# Altium schematic sheet OpenBeacon.SchDoc
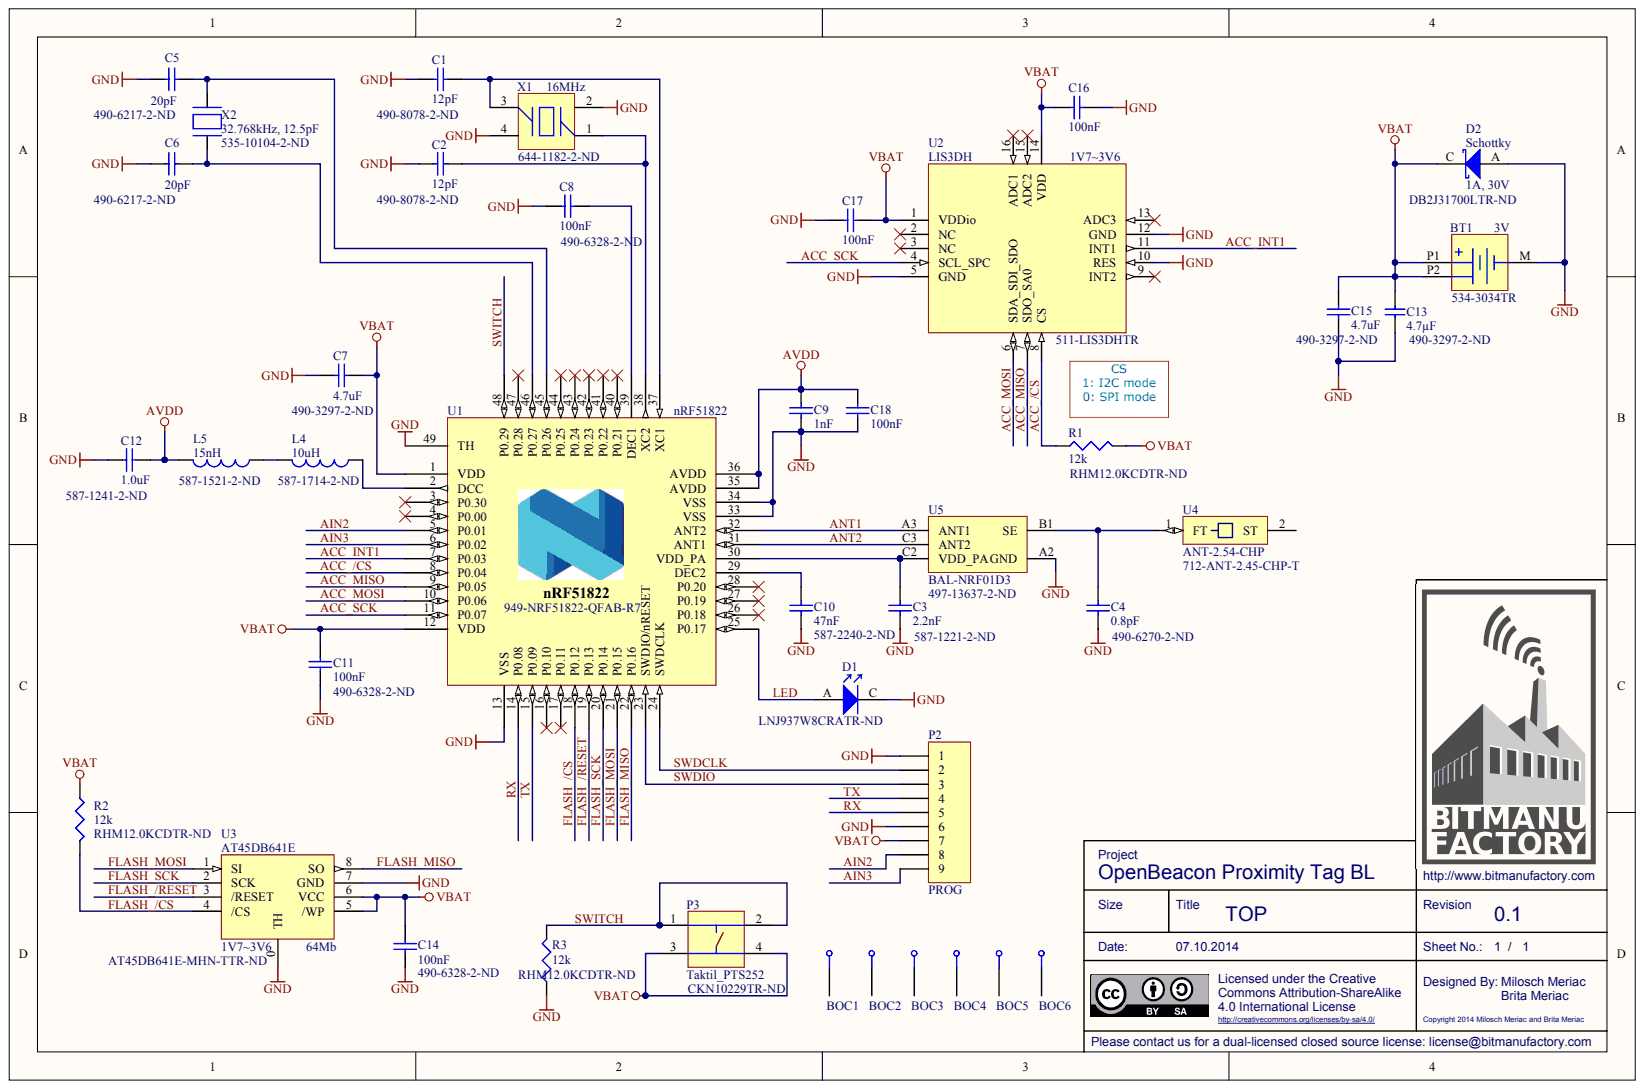
[diagram p0: OpenBeacon.SchDoc]

## Parts
BOC1  Testpad  (value not drawn)
BOC2  Testpad  (value not drawn)
BOC3  Testpad  (value not drawn)
BOC4  Testpad  (value not drawn)
BOC5  Testpad  (value not drawn)
BOC6  Testpad  (value not drawn)
BT1  value 3V  Supplier Part Number 1: 534-3034TR  (hidden comment: Battery Cell Holder)
C1  12pF
C2  Supplier Part Number 1: 490-8078-2-ND  (hidden comment: 12pF)
C3  Supplier Part Number 1: 587-1221-2-ND  (hidden comment: 2.2nF)
C4  Supplier Part Number 1: 490-6270-2-ND  (hidden comment: 0.8pF)
C5  value 20pF  (hidden comment: Cap 20pF)
C6  value 20pF  Supplier Part Number 1: 490-6217-2-ND  (hidden comment: Cap 20pF)
C7  Supplier Part Number 1: 490-3297-2-ND  (hidden comment: 4.7uF)
C8  Supplier Part Number 1: 490-6328-2-ND  (hidden comment: 100nF)
C9  1nF
C10  Supplier Part Number 1: 587-2240-2-ND  (hidden comment: 47nF)
C11  Supplier Part Number 1: 490-6328-2-ND  (hidden comment: 100nF)
C12  Supplier Part Number 1: 587-1241-2-ND  (hidden comment: 1.0uF)
C13  Supplier Part Number 1: 490-3297-2-ND  [0603]  (hidden comment: 4.7µF)
C14  Supplier Part Number 1: 490-6328-2-ND  (hidden comment: 100nF)
C15  Supplier Part Number 1: 490-3297-2-ND  (hidden comment: 4.7uF)
C16  100nF
C17  100nF
C18  100nF
D1  Supplier Part Number 1: LNJ937W8CRATR-ND  (hidden comment: LED blue)
D2  Schottky  value 1A, 30V  Supplier Part Number 1: DB2J31700LTR-ND
L4  Supplier Part Number 1: 587-1714-2-ND  (hidden comment: 10uH)
L5  Supplier Part Number 1: 587-1521-2-ND  (hidden comment: 15nH)
P2  PROG
P3  Taktil_PTS252  Supplier Part Number 1: CKN10229TR-ND
R1  Supplier Part Number 1: RHM12.0KCDTR-ND  (hidden comment: 12k)
R2  Supplier Part Number 1: RHM12.0KCDTR-ND  (hidden comment: 12k)
R3  Supplier Part Number 1: RHM12.0KCDTR-ND  (hidden comment: 12k)
U1  nRF51822  Supplier Part Number 1: 949-NRF51822-QFAB-R7
U2  LIS3DH  supply voltage: 1V7~3V6  Supplier Part Number 1: 511-LIS3DHTR
U3  AT45DB641E  value 64Mb  supply voltage: 1V7~3V6  Supplier Part Number 1: AT45DB641E-MHN-TTR-ND
U4  ANT-2.54-CHP  Supplier Part Number 1: 712-ANT-2.45-CHP-T
U5  BAL-NRF01D3
X1  value 16MHz  Supplier Part Number 1: 644-1182-2-ND  (hidden comment: XTAL_4Pin)
X2  value 32.768kHz, 12.5pF  Supplier Part Number 1: 535-10104-2-ND  (hidden comment: XTAL-2pin)

## Nets
AVDD: C9.1 C12.1 C18.1 L5.2 U1.35(AVDD) U1.36(AVDD)
GND: BT1.M C1.2 C2.2 C3.2 C4.2 C5.1 C6.1 C7.2 C8.2 C9.2 C10.2 C11.2 C12.2 C13.1 C14.2 C15.2 C16.1 C17.2 C18.2 D1.C D2.A P2.1 P2.6 R3.2 U1.13(VSS) U1.33(VSS) U1.34(VSS) U1.49(TH) U2.5(GND) U2.10(RES) U2.12(GND) U3.0(TH) U3.7(GND) U5.A2(GND) X1.2 X1.4
VBAT: BT1.P1 BT1.P2 C7.1 C11.1 C13.2 C14.1 C15.1 C16.2 C17.1 D2.C P2.7 P3.3 P3.4 R1.2 R2.1 U1.1(VDD) U1.12(VDD) U2.1(VDDio) U2.14(VDD) U3.5(/WP) U3.6(VCC)
ACC_/CS: R1.1 U1.8(P0.04) U2.8(CS)
ACC_INT1: U1.7(P0.03) U2.11(INT1)
ACC_MISO: U1.9(P0.05) U2.7(SDO_SA0)
ACC_MOSI: U1.10(P0.06) U2.6(SDA_SDI_SDO)
ACC_SCK: U1.11(P0.07) U2.4(SCL_SPC)
AIN2: P2.8 U1.5(P0.01)
AIN3: P2.9 U1.6(P0.02)
ANT1: U1.32(ANT2) U5.A3(ANT1)
ANT2: U1.31(ANT1) U5.C3(ANT2)
FLASH_/CS: R2.2 U1.18(P0.12) U3.4(/CS)
FLASH_/RESET: U1.19(P0.13) U3.3(/RESET)
FLASH_MISO: U1.22(P0.16) U3.8(SO)
FLASH_MOSI: U1.21(P0.15) U3.1(SI)
FLASH_SCK: U1.20(P0.14) U3.2(SCK)
LED: D1.A U1.25(P0.17)
RX: P2.5 U1.14(P0.08)
SWDCLK: P2.2 U1.24(SWDCLK)
SWDIO: P2.3 U1.23(SWDIO/nRESET)
SWITCH: P3.1 P3.2 R3.1 U1.48(P0.29)
TX: P2.4 U1.15(P0.09)
NetC1_1: C1.1 U1.37(XC1) X1.3
NetC2_1: C2.1 U1.38(XC2) X1.1
NetC3_1: C3.1 U1.30(VDD_PA) U5.C2(VDD_PA)
NetC4_1: C4.1 U4.1 U5.B1(SE)
NetC5_2: C5.2 U1.45(P0.26) X2.2
NetC6_2: C6.2 U1.46(P0.27) X2.1
NetC8_1: C8.1 U1.39(DEC1)
NetC10_1: C10.1 U1.29(DEC2)
NetL4_1: L4.1 U1.2(DCC)
NetL4_2: L4.2 L5.1

Not connected: BOC1 1; BOC2 1; BOC3 1; BOC4 1; BOC5 1; BOC6 1; U1 3(P0.30) 4(P0.00) 16(P0.10) 17(P0.11) 26(P0.18) 27(P0.19) 28(P0.20) 40(P0.21) 41(P0.22) 42(P0.23) 43(P0.24) 44(P0.25) 47(P0.28); U2 2(NC) 3(NC) 9(INT2) 13(ADC3) 15(ADC2) 16(ADC1); U4 2

## Library data of the parts
C1 C2 = (no symbol name): tolerance: 2%, NPO; note: 50V; value: 12pF; Supplier 1: Digi-Key
  C1: Supplier Part Number 1: 490-8078-2-ND
C3 C9 = (no symbol name): tolerance: X7R, ±10%; note: 50V; Supplier 1: Digi-Key
  C3: value: 2.2nF
  C9: value: 1nF; Supplier Part Number 1: 490-1303-2-ND
C4 = (no symbol name): tolerance: NP0, ±5%; note: 50V; value: 0.8pF; Supplier 1: Digi-Key
C7 C15 = (no symbol name): tolerance: X5R, ±10%; note: 6V3; value: 4.7uF; Supplier 1: Digi-Key
C8 C11 C12 C14 C16-C18 = (no symbol name): tolerance: 10%, X7R; note: 16V; Supplier 1: Digi-Key
  C8 C11 C14: value: 100nF
  C12: value: 1.0uF
  C16-C18: value: 100nF; Supplier Part Number 1: 490-6328-2-ND
C10 = (no symbol name): tolerance: X7R, ±10%; note: 25V; value: 47nF; Supplier 1: Digi-Key
C13 = (no symbol name): Part Number: C0603-4µ7; Note: 6.3V; Artikkelnr Noca: 20069060; Manufacturer: *; Manufacturer Part Number: *; Datasheet: *; LatestRevisionDate: 2009-11-23; Pin Count: 2; Published: 2009-05-08; Value: 4.7µF; Supplier: *; Supplier part number: *; 2nd source Supplier: *; 2nd source part number: *; Supplier 1: Digi-Key; Publisher: Nordic Semiconductor
D1 = (no symbol name): value: 20mA; note: BLUE; Supplier 1: Digi-Key
L4 = (no symbol name): tolerance: 10%; note: I_DC-min=80mA; Value: 10uH; Supplier 1: Digi-Key
L5 = (no symbol name): tolerance: 5%; note: I_DC-min=320mA; Value: 15nH; Supplier 1: Digi-Key
R1-R3 = (no symbol name): tolerance: 1%; note: 0.063W; Value: 12k; Supplier 1: Digi-Key
BOC1-BOC6 BT1 C5 C6 D2 P2 P3 U1-U5 X1 X2 = (no symbol name)
  BT1: note: Keystone 3034; Supplier 1: Mouser
  C5: Supplier 1: Digi-Key; Supplier Part Number 1: 490-6217-2-ND
  C6 D2 P3 U3 X1 X2: Supplier 1: Digi-Key
  U1 U2 U4: Supplier 1: Mouser
  U5: note: BAL-NRF01D3; Supplier 1: Digi-Key; Supplier Part Number 1: 497-13637-2-ND
